# Altium schematic sheet PressureandTemperature.SchDoc
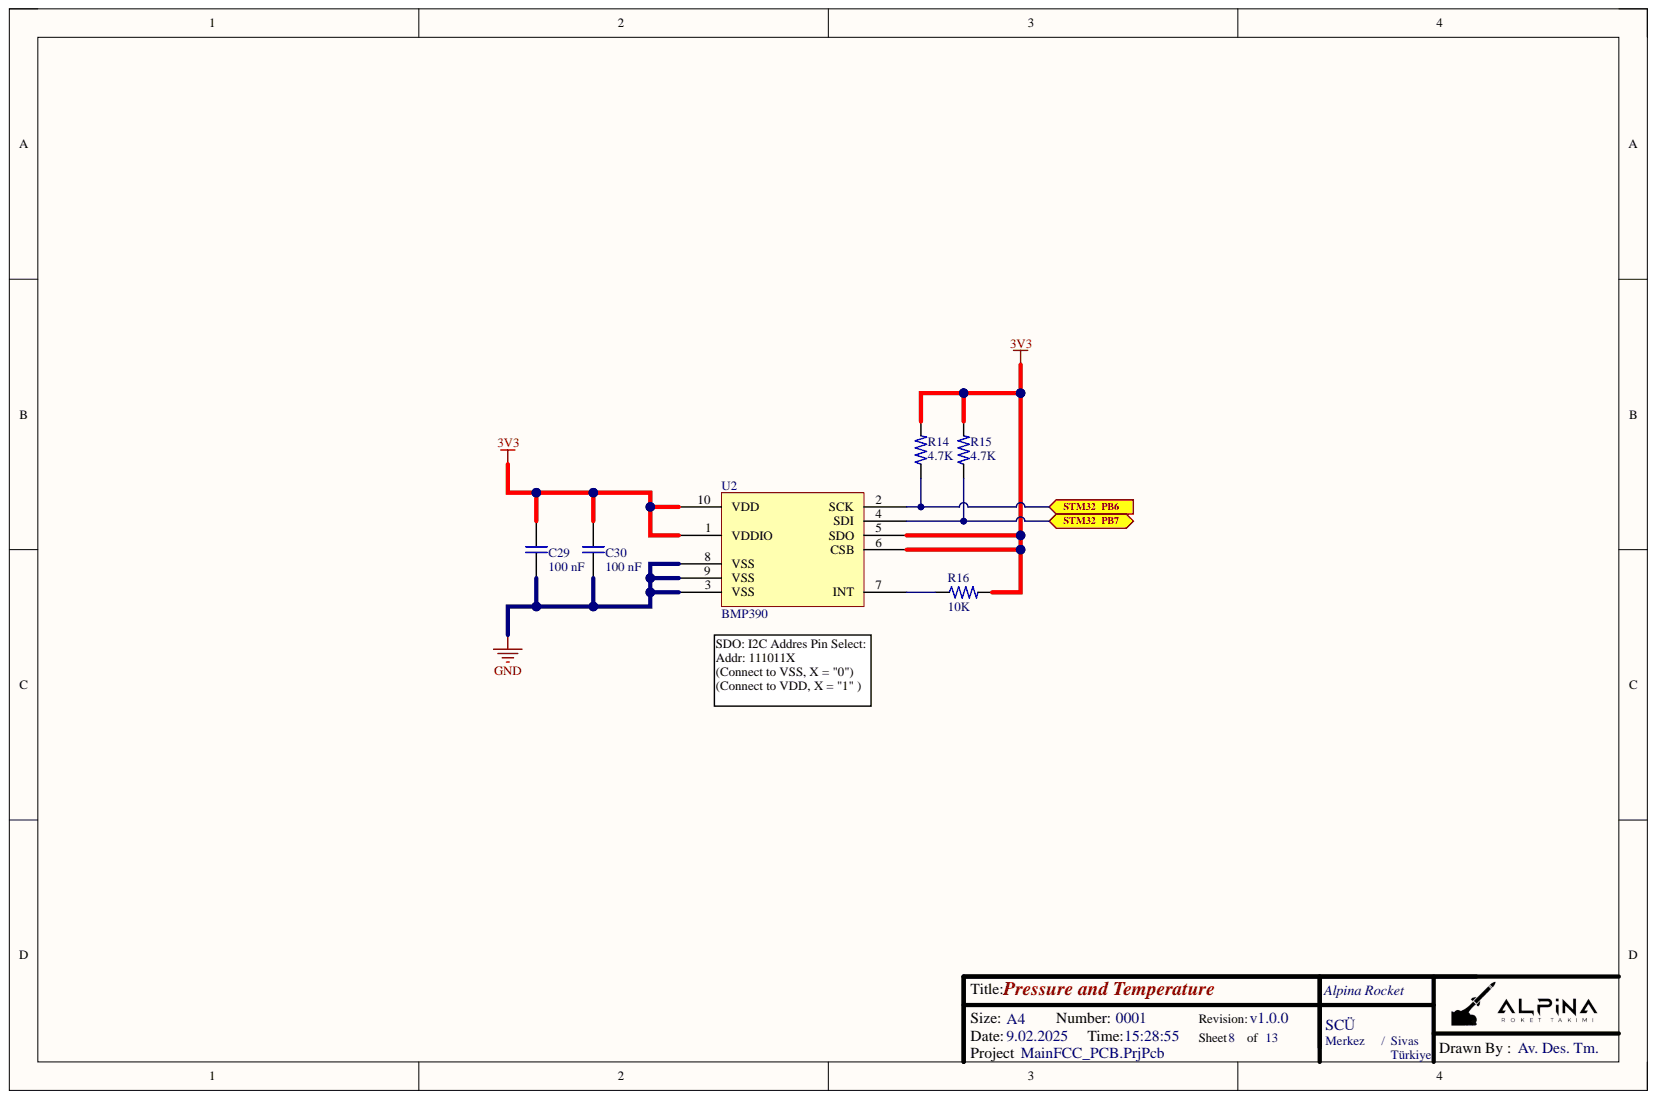
[diagram p0: PressureandTemperature.SchDoc]

## Parts
C29  100 nF  [MergenElektronik_Capacitors_Ceramics_SMDs_0603_M_NS]
C30  100 nF  [MergenElektronik_Capacitors_Ceramics_SMDs_0603_M_NS]
R14  4.7K  [MergenElektronik_Resistors_ChipResistors_0603_M_NS]
R15  4.7K  [MergenElektronik_Resistors_ChipResistors_0603_M_NS]
R16  10K  [MergenElektronik_Resistors_ChipResistors_0603_M_NS]
U2  BMP390  [MergenElektronik_Sensors_PressureSensors_10-WFLGA]

## Ports
STM32_PB6, STM32_PB7

## Nets
3V3: C29.1 C30.1 R14.2 R15.2 R16.2 U2.1(VDDIO) U2.5(SDO) U2.6(CSB) U2.10(VDD)
GND: C29.2 C30.2 U2.3(VSS) U2.8(VSS) U2.9(VSS)
STM32_PB6: R14.1 U2.2(SCK) ; port STM32_PB6
STM32_PB7: R15.1 U2.4(SDI) ; port STM32_PB7
NetR16_1: R16.1 U2.7(INT)

## Library data of the parts
C29 C30 = MergenElektronik_Capacitors_Ceramic_SMDs_100nF_100V: Mergen Elektronik - Capacitors - Ceramics - SMDs - 0603 - 100 nF - 100V; Manufacturer: Samsung Electro-Mechanics; Manufacturer Number: CL10B104KC8NNNC; Model: Ceramic Capacitors; Tolerance: ±10%; Component Kind: Standard; Digi-Key Number: 1276-6807-1-ND; Farnell Number: 3013412; Library Name: MergenElektronik_Capacitors_Ceramics_SMDs.SchLib; Mounting Type: Surface Mount; Mouser Number: 187-CL10B104KC8NNNC; Package: 0603(1608 Metric); Supplier 1: Digikey; Supplier 2: Mouser; Supplier Part Number 1: 1276-6807-1-ND; Supplier Part Number 2: 187-CL10B104KC8NNNC; Temperature: -55°C up to +125°C; Value: 100 nF; Voltage Rating: 100V; Özdisan Number: CL10B104KC8NNNC
R14 R15 = MergenElektronik_Resistors_ChipResistors_0603_4.7K: Mergen Elektronik - Resistors - Chip Resistors - 0603 - 4.7K; Manufacturer: Stackpole Electronics Inc; Manufacturer Number: RMCF0603FT4K70; Power: 1/10W; Tolerance: ±1%; Value: Chip Resistor - 0603 - 4.7K; Component Kind: Standard; Digi-Key Number: RMCF0603FT4K70TR-ND; Library Name: MergenElektronik_Resistors_ChipResistors.IntLib; Model: Chip Resistor; Mounting Type: Surface Mount; Mouser Number: 708-RMCF0603FT4K70; Package: 0603(1608); Supplier 1: Digikey; Supplier 2: Mouser; Supplier Part Number 1: RMCF0603FT4K70TR-ND; Supplier Part Number 2: 708-RMCF0603FT4K70; Temperature: -55°C up to +155°C - X8R
R16 = MergenElektronik_Resistors_ChipResistors_0603_10K: Mergen Elektronik - Resistors - Chip Resistors - 0603 - 10K; Manufacturer: Stackpole Electronics Inc; Manufacturer Number: RMCF0603JT10K0; Power: 1/10W; Tolerance: ±5%; Value: Chip Resistor - 0603 - 10K; Component Kind: Standard; Digi-Key Number: RMCF0603JT10K0CT-ND; Library Name: MergenElektronik_Resistors_ChipResistors.IntLib; Model: Chip Resistor; Mounting Type: Surface Mount; Package: 0603(1608); Supplier 1: Digi-Key; Supplier Part Number 1: RMCF0603JT10K0-ND; Temperature: -55°C up to +155°C - X8R
U2 = MergenElektronik_Sensors_PressureSensors_BMP390: Mergen Elektronik - Sensors - Pressure Sensors - BMP390; Manufacturer: Bosch Sensortec; Operating Pressure: 4.35PSI ~ 18.13PSI (30kPa ~ 125kPa); Operrating Supply Voltage: 1.65V up to 3.6V; Output Type: I2C, SPI; Tolerance: ±0.01PSI (0.05kPa); Component Kind: Standard; Digi-Key Number: 828-BMP390TR-ND; Library Name: MergenElektronik_Sensors_PressureSensors.IntLib; Manufacturer Number: BMP390; Model: Pressure Sensor; Mounting Type: Surface Mount; Mouser Number: 262-BMP390; Package: 10-WFLGA; Supplier 1: Digikey; Supplier 2: Mouser; Supplier Number 1: 828-BMP390TR-ND; Supplier Number 2: 262-BMP390; Temperature: -40°C up to 85°C (TA); Value: BMP390; Özdisan Number: BMP390
